# Altium schematic sheet LEDs.SchDoc
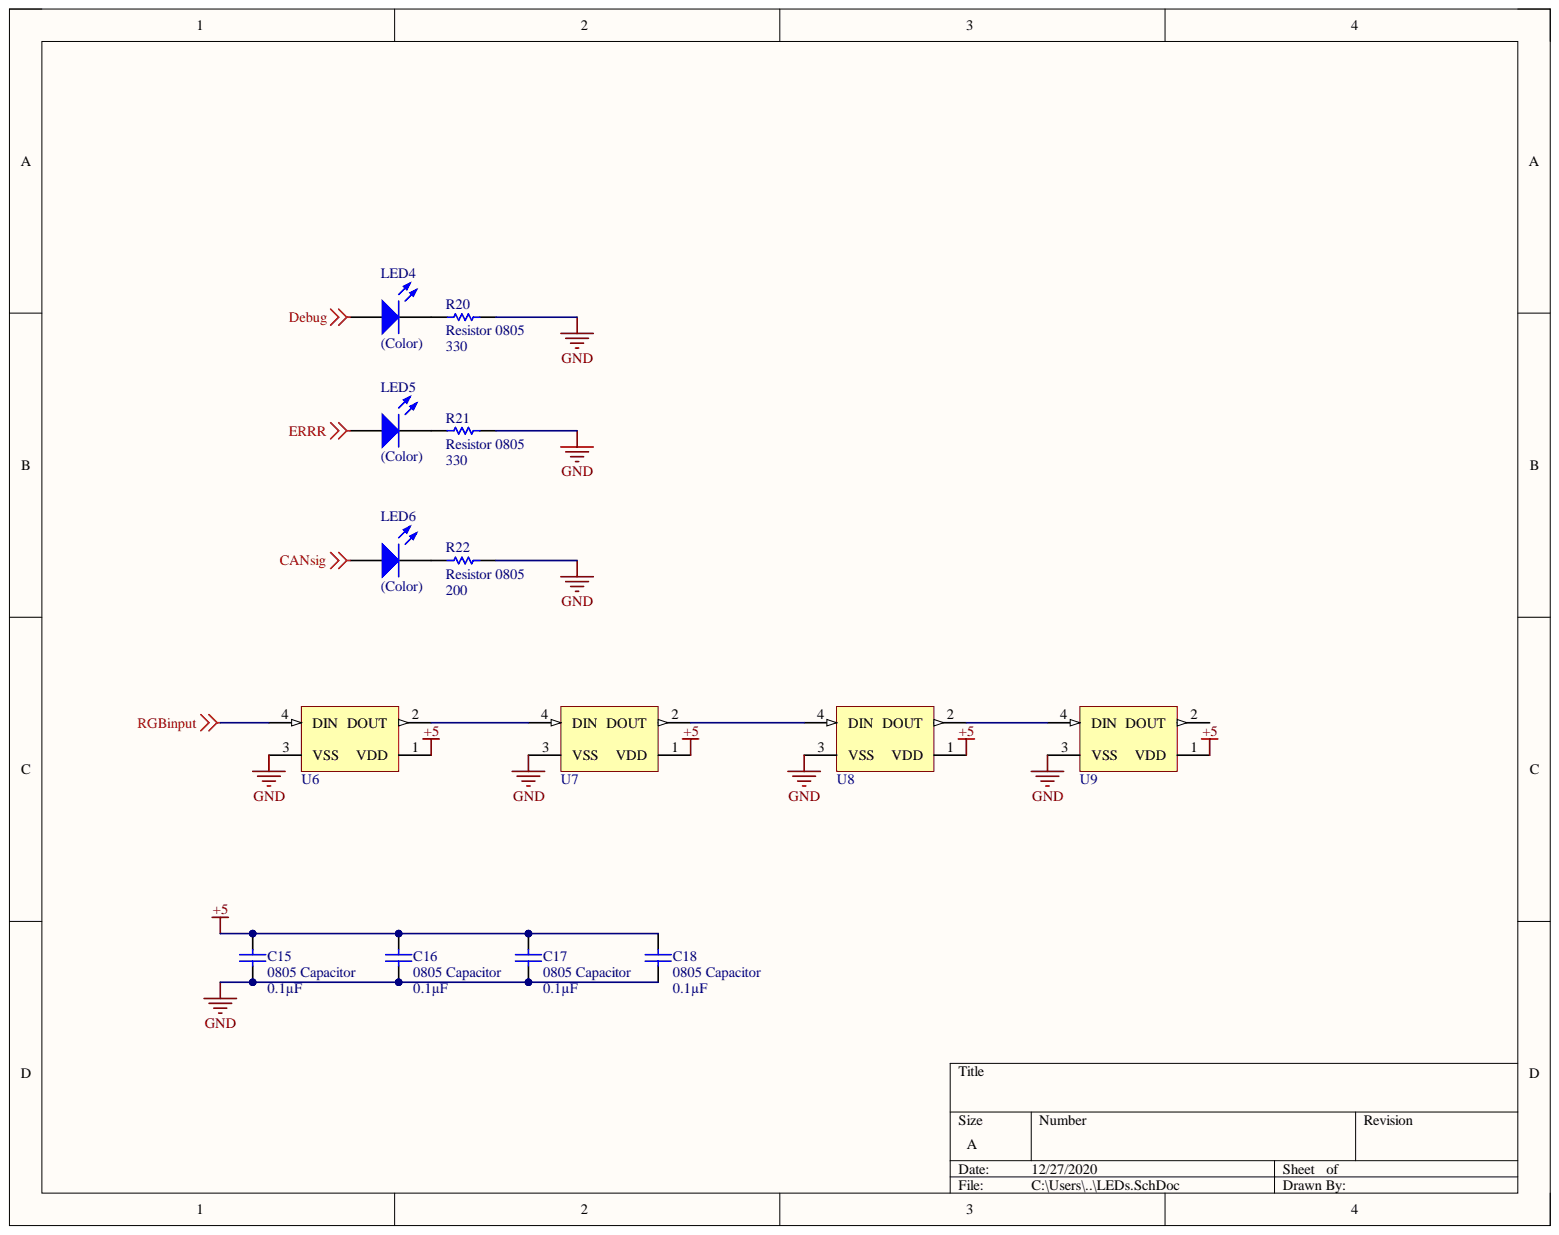
[diagram p0: LEDs.SchDoc]

## Parts
C15  0805 Capacitor  value 0.1µF  Case Size/Dimension: 0805  [Cap 0805]
C16  0805 Capacitor  value 0.1µF  Case Size/Dimension: 0805  [Cap 0805]
C17  0805 Capacitor  value 0.1µF  Case Size/Dimension: 0805  [Cap 0805]
C18  0805 Capacitor  value 0.1µF  Case Size/Dimension: 0805  [Cap 0805]
LED4  LED  value (Color)  [0805_A]
LED5  LED  value (Color)  [0805_A]
LED6  LED  value (Color)  [0805_A]
R20  Resistor 0805  value 330  Case-EIA: 0805  [RESC0805(2012)_N]
R21  Resistor 0805  value 330  Case-EIA: 0805  [RESC0805(2012)_N]
R22  Resistor 0805  value 200  Case-EIA: 0805  [RESC0805(2012)_N]
U6  RBG_LED  [RGB_LED_1]  (value not drawn)
U7  RBG_LED  [RGB_LED_1]  (value not drawn)
U8  RBG_LED  [RGB_LED_1]  (value not drawn)
U9  RBG_LED  [RGB_LED_1]  (value not drawn)

## Nets
+5: C15.2 C16.2 C17.2 C18.2 U6.1(VDD) U7.1(VDD) U8.1(VDD) U9.1(VDD)
CANsig: LED6.2
Debug: LED4.2
ERRR: LED5.2
GND: C15.1 C16.1 C17.1 C18.1 R20.2 R21.2 R22.2 U6.3(VSS) U7.3(VSS) U8.3(VSS) U9.3(VSS)
RGBinput: U6.4(DIN)
NetLED4_1: LED4.1 R20.1
NetLED5_1: LED5.1 R21.1
NetLED6_1: LED6.1 R22.1
NetU6_2: U6.2(DOUT) U7.4(DIN)
NetU7_2: U7.2(DOUT) U8.4(DIN)
NetU8_2: U8.2(DOUT) U9.4(DIN)

Not connected: U9 2(DOUT)

## Library data of the parts
C15-C18 = CMP-002-0000-1: Standard Capacitor; Tolerance (%): ±10%; Component Kind: Standard; Component Type: Standard; ComponentLink1Description: Digi-Key_Purchase_URL; ComponentLink1URL: https://www.digikey.com/product-detail/en/kemet/C0805C104Z5VACTU/399-1177-1-ND/411452; ComponentLink2Description: Datasheet Link; ComponentLink2URL: https://content.kemet.com/datasheets/KEM_C1005_Y5V_SMD.pdf; Design Item ID: 0805 Capacitor; Digi-Key_Part_Number: 399-1177-1-ND; Digikey URL: https://www.digikey.com/product-detail/en/kemet/C0805C104Z5VACTU/399-1177-1-ND/411452; Mounting Technology: SurfaceMount; Package Description: 2-Pin Surface Mount Device, Body 2.01 x 1.25 mm; Package Reference: 0805; Pin Count: 2; Source: Standard Cap.SchLib; Library Name: University of Washington - Husky Robotics Team
LED4-LED6 = CMP-010-0001-1: Standard 0805 LED; Manufacturer: Wuerth Elektronik; Component Kind: Standard; Component Type: Standard; ComponentLink1Description: Manufacturer URL; ComponentLink2Description: Datasheet; Design Item ID: LED; PackageReference: 0805_A; Pin Count: 2; Source: Standard LED.SchLib; Library Name: University of Washington - Husky Robotics Team
R20-R22 = CMP-006-0001-1: Generic 0805 Resistor; Case-Metric: 2012; Power: 0.125 W; Tolerance: 5 %; Component Kind: Standard; Component Type: Standard; ComponentLink1Description: Digi-Key_Purchase_Link; ComponentLink1URL: https://www.digikey.com/product-detail/en/rohm-semiconductor/ESR10EZPJ121/RHM120KCT-ND/1762959; ComponentLink2Description: Datasheet Link; ComponentLink2URL: https://d1d2qsbl8m0m72.cloudfront.net/en/products/databook/datasheet/passive/resistor/chip_resistor/esr-e.pdf; Design Item ID: Resistor 0805; Digi-Key_Part_Number: RHM120KCT-ND; Pin Count: 2; Technology: SMT; Library Name: University of Washington - Husky Robotics Team; Source: CAN Termination Resistor 0805.SchLib
U6-U9 = CMP-003-0014-1: LED ADAI-WS2812B-4; Component Kind: Standard; Component Type: Standard; ComponentLink1Description: Digi-Key_Purchase_URL; ComponentLink1URL: https://www.digikey.com/en/products/detail/broadcom-limited/ASMB-MTB1-0A3A2/5695366; ComponentLink2Description: Datasheet_Link; ComponentLink2URL: https://docs.broadcom.com/docs/AV02-4194EN; Design Item ID: RBG_LED; Digi-Key_Part_Number: 516-3280-1-ND; Pin Count: 4; Source: LED_RGB.SchLib; Library Name: University of Washington - Husky Robotics Team
